# Altium schematic sheet Status_LEDs.SchDoc
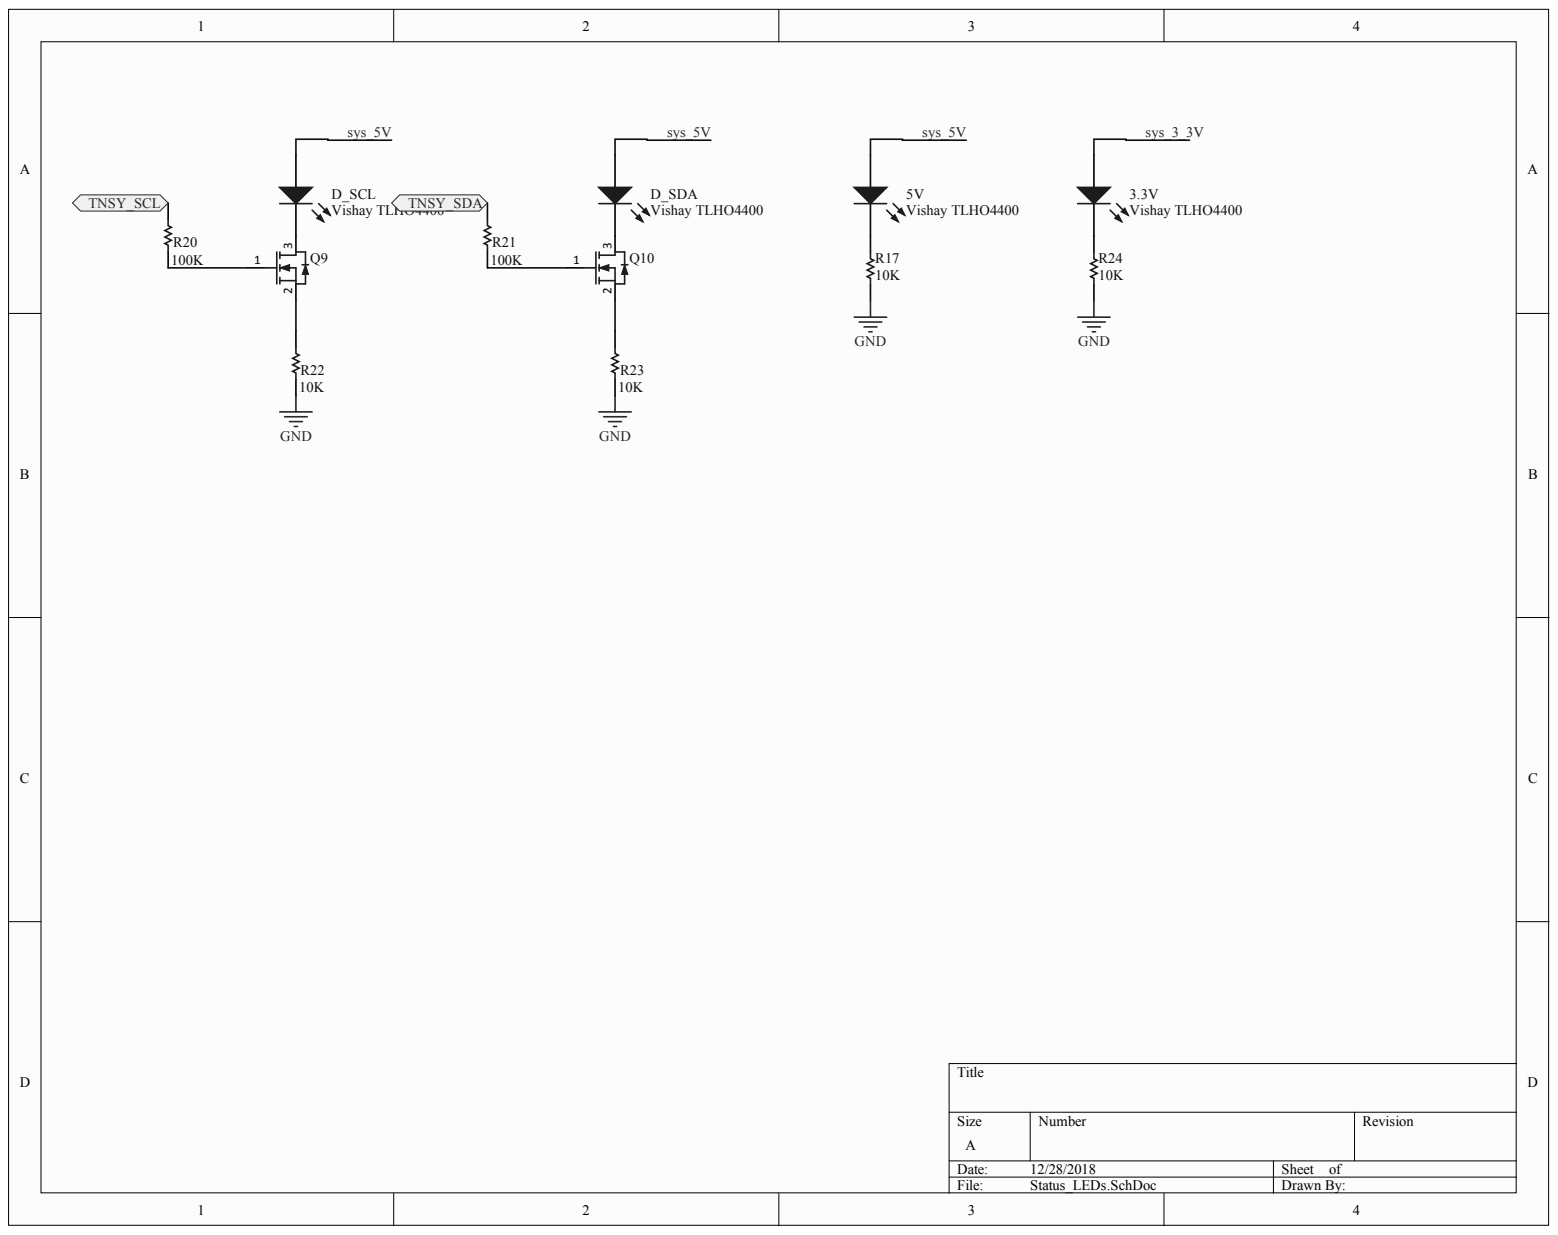
[diagram p0: Status_LEDs.SchDoc]

## Parts
3.3V  Vishay TLHO4400  [PCB-13611427-1]
5V  Vishay TLHO4400  [PCB-13611427-1]
D_SCL  Vishay TLHO4400  [PCB-13611427-1]
D_SDA  Vishay TLHO4400  [PCB-13611427-1]
Q9  Rohm RK7002BMT116  [PCB-lhick5qix2l1q5xknwuk-1]  (value not drawn)
Q10  Rohm RK7002BMT116  [PCB-lhick5qix2l1q5xknwuk-1]  (value not drawn)
R17  Resistance: 10K  [PCB-xnm56hls5we6bt40qwox-1]  (hidden comment: SYM-fea435dd441a2163-1)
R20  Resistance: 100K  [PCB-xnm56hls5we6bt40qwox-1]  (hidden comment: SYM-fea435dd441a2163-1)
R21  Resistance: 100K  [PCB-xnm56hls5we6bt40qwox-1]  (hidden comment: SYM-fea435dd441a2163-1)
R22  Resistance: 10K  [PCB-xnm56hls5we6bt40qwox-1]  (hidden comment: SYM-fea435dd441a2163-1)
R23  Resistance: 10K  [PCB-xnm56hls5we6bt40qwox-1]  (hidden comment: SYM-fea435dd441a2163-1)
R24  Resistance: 10K  [PCB-xnm56hls5we6bt40qwox-1]  (hidden comment: SYM-fea435dd441a2163-1)

## Ports
TNSY_SCL, TNSY_SDA

## Nets
GND: R17.2 R22.1 R23.1 R24.2
SYS_3_3V: 3.3V.1
SYS_5V: 5V.1 D_SCL.1 D_SDA.1
TNSY_SCL: R20.2 ; port TNSY_SCL
TNSY_SDA: R21.2 ; port TNSY_SDA
Net3.3V_2: 3.3V.2 R24.1
Net5V_2: 5V.2 R17.1
NetD_SCL_2: D_SCL.2 Q9.3
NetD_SDA_2: D_SDA.2 Q10.3
NetQ9_1: Q9.1 R20.1
NetQ9_2: Q9.2 R22.2
NetQ10_1: Q10.1 R21.1
NetQ10_2: Q10.2 R23.2

## Library data of the parts
3.3V 5V D_SCL D_SDA = CMP-522e98692a768de9-3: LED; Red; 4mcd; 3mmDia.; T-1; 30mA; 2.4V; 605nm; +/-30deg; GaAsP on GaP; 15V; 100mW; Lead-Free Status: Lead Free; Lead Length: 0.023 m; Lens Type: Diffused; Luminous Intensity: 0.004 cd; Peak Wavelength: 6.05e-07 m; Power Dissipation: 0.1 W; Viewing Angle: 30 °; Wavelength: 6.11e-07, 6.05e-07 m; Color: Orange; Component Kind: Standard; Forward Voltage: 2.4 V; Library Name: CommunityVault; Manufacturer Part Number: TLHO4400; Mounting Style: Through Hole, PCB; Number of Pins: 2; OctopartID: 522e98692a768de9; Octopart URL: http://octopart.com/tlho4400-vishay-55401790; Packaging: Bulk; RoHS: Compliant; Size-Diameter: 0.003 m
Q9 Q10 = CMP-c6120e73f56f19d5-3: N-Channel 60 V 0.2 W Surface Mount 2.5 V Drive Silicon Power Mosfet - SOT-23; Component Kind: Standard; Library Name: CommunityVault; Mounting Style: Surface Mount; Octopart URL: http://octopart.com/rk7002bmt116-rohm-23795227
R17 R20-R24 = CMP-fea435dd441a2163-1: R Series Axial Through Hole Fixed Resistor 10Ohms +/-0.1% 0.25W +/-15ppm/degC; Manufacturer Part Number: YR1B10RCC; ELV Compliant: Compliant; Power Rating: 250 mW; Resistance: 10 Ω; Resistance Tolerance: ±0.1%; Voltage Rating (DC): 500 V; Component Kind: Standard; Library Name: CommunityVault; Number of Pins: 2; Packaging: Ammo Pack; RoHS: Compliant
